# Altium schematic sheet HB_Z6.3.2_HVPSU.SchDoc(HB_Z6.3.2_HVPSU)
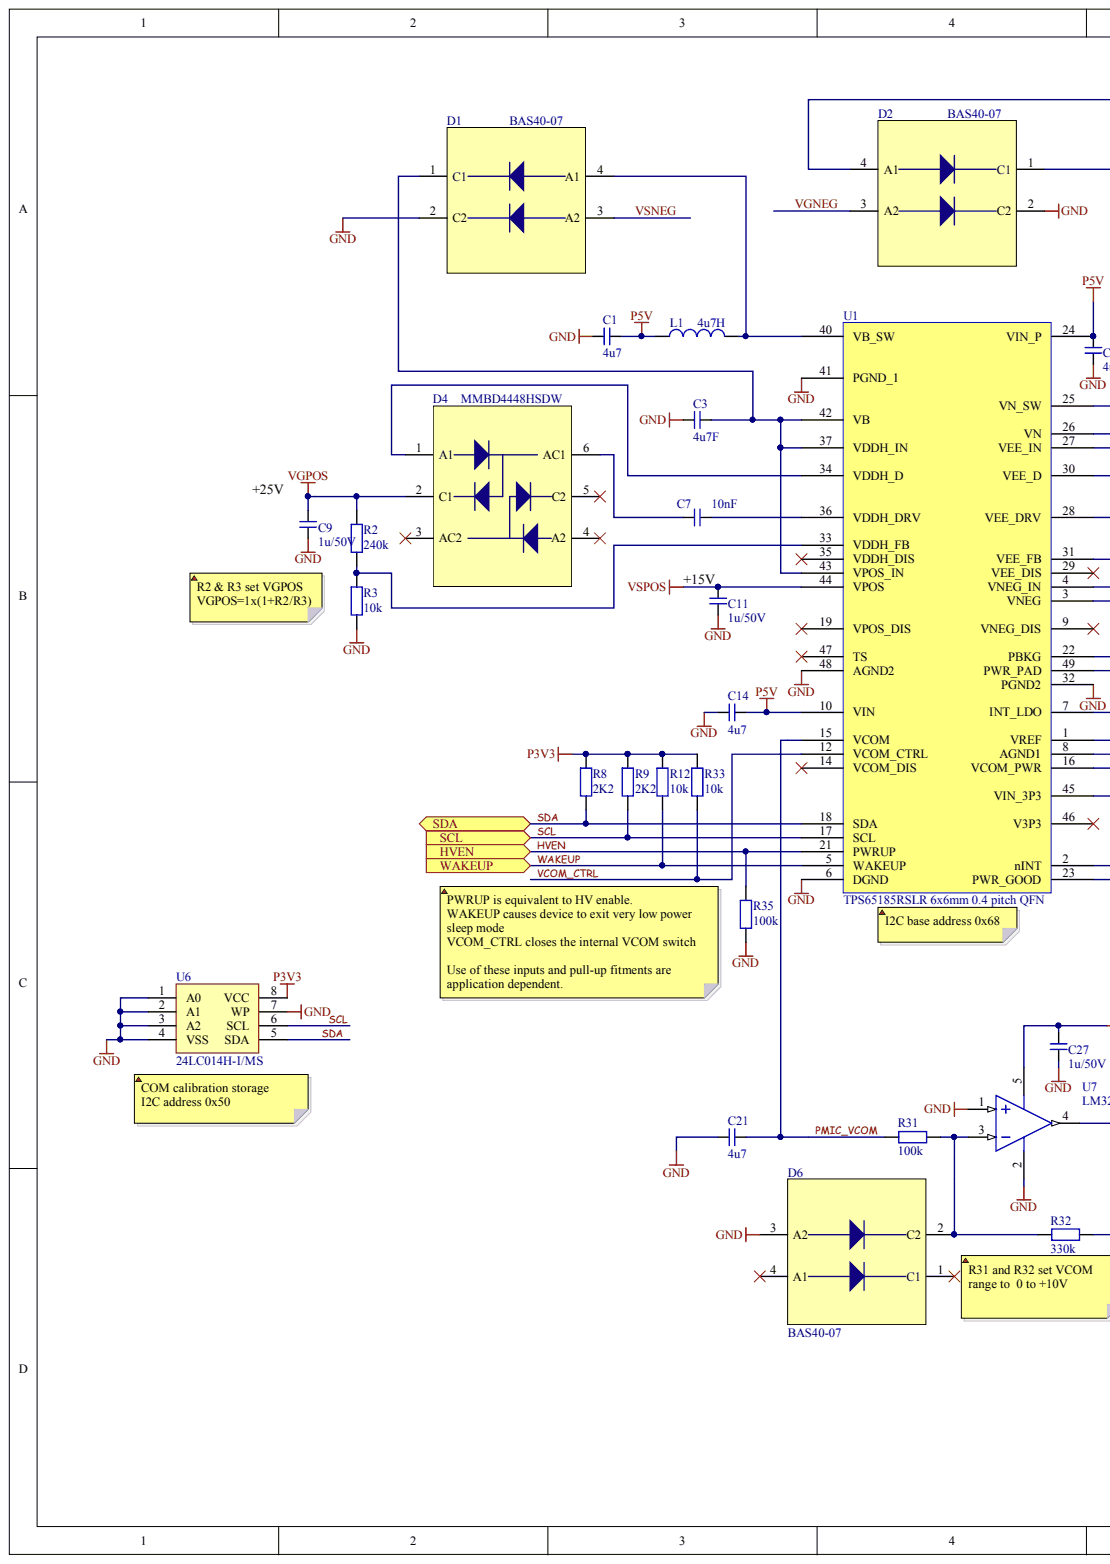
[diagram p0: HB_Z6.3.2_HVPSU.SchDoc, left]
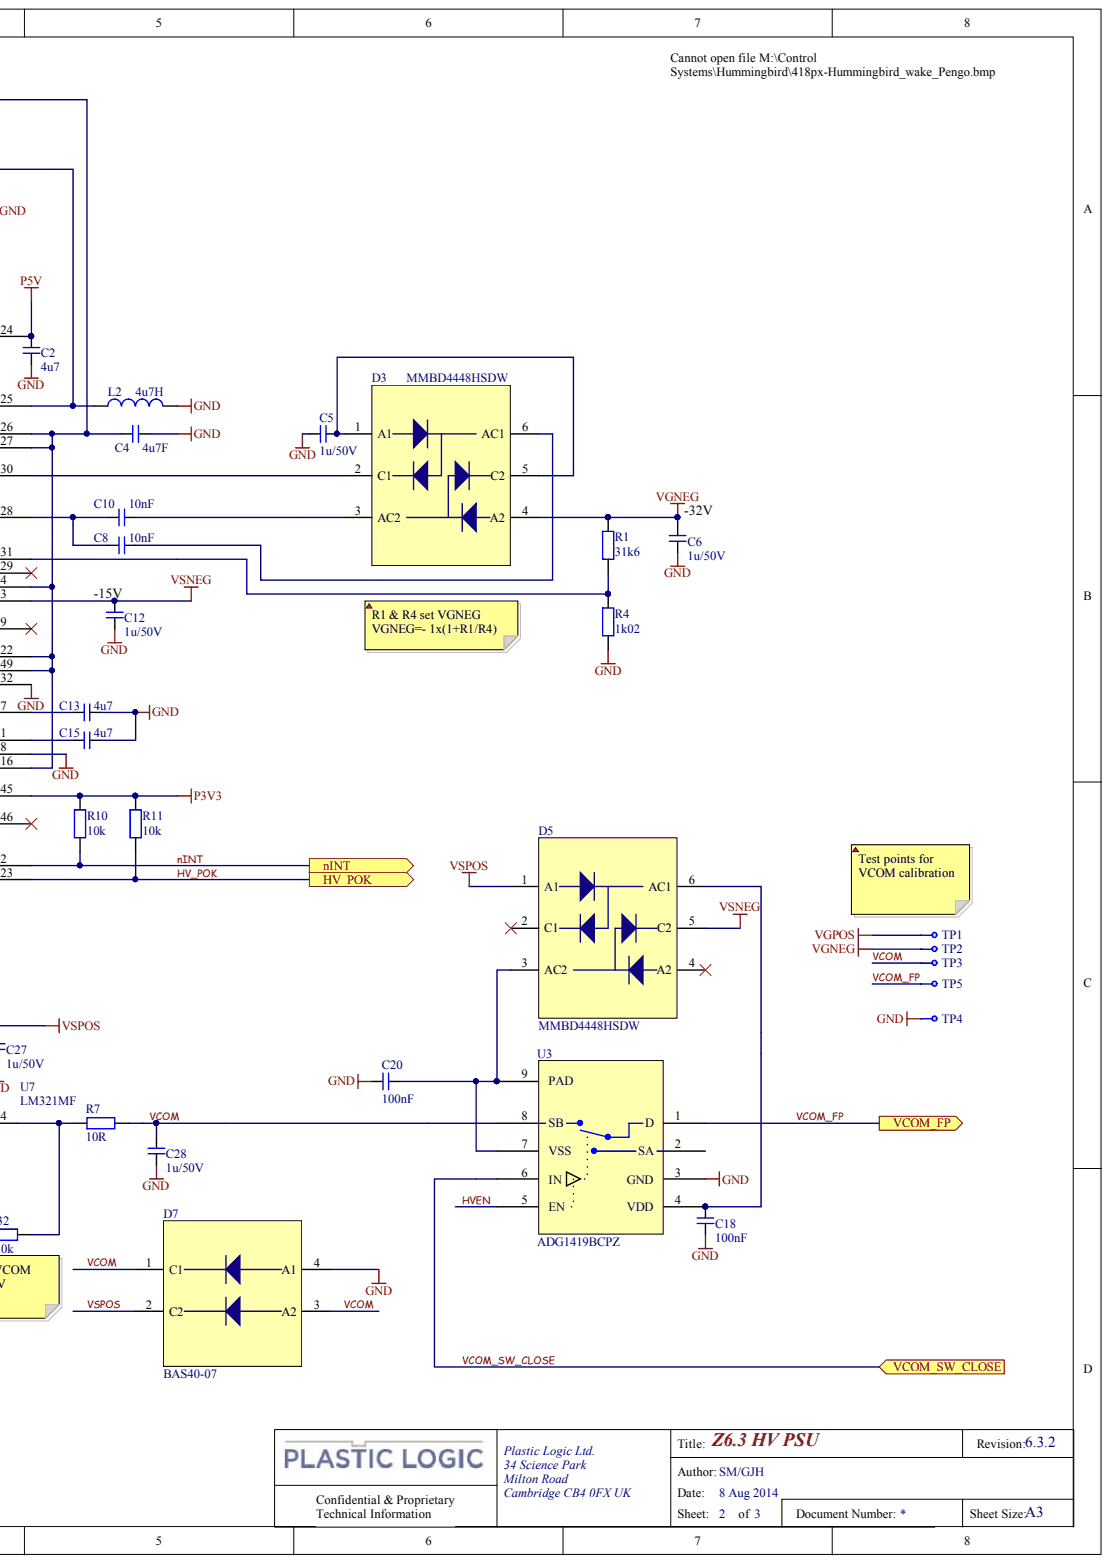
[diagram p1: HB_Z6.3.2_HVPSU.SchDoc, right]

## Parts
C1  4u7  [C0402]
C2  4u7  [C0402]
C3  4u7F  [C0805]
C4  4u7F  [C0805]
C5  1u/50V  [C0603_small]
C6  1u/50V  [C0603_small]
C7  10nF  [C0402]
C8  10nF  [C0402]
C9  1u/50V  [C0603_small]
C10  10nF  [C0402]
C11  1u/50V  [C0603_small]
C12  1u/50V  [C0603_small]
C13  4u7  [C0402]
C14  4u7  [C0402]
C15  4u7  [C0402]
C18  100nF  [C0603_small]
C20  100nF  [C0603_small]
C21  4u7  [C0402]
C27  1u/50V  [C0603_small]
C28  1u/50V  [C0603_small]
D1  BAS40-07  [SOT-143]
D2  BAS40-07  [SOT-143]
D3  MMBD4448HSDW  [SOT-363]
D4  MMBD4448HSDW  [SOT-363]
D5  MMBD4448HSDW  [SOT-363]
D6  BAS40-07  [SOT-143]
D7  BAS40-07  [SOT-143]
L1  4u7H  [Panasonic ELLVGG]
L2  4u7H  [Panasonic ELLVGG]
R1  31k6  [R0402]
R2  240k  [R0402]
R3  10k  [R0402]
R4  1k02  [R0402]
R7  10R  [R0402]
R8  2K2  [R0402]
R9  2K2  [R0402]
R10  10k  [R0402]
R11  10k  [R0402]
R12  10k  [R0402]
R31  100k  [R0402]
R32  330k  [R0402]
R33  10k  [R0402]
R35  100k  [R0402]
TP1  Test Pad  [Test Pad 1mm]  (value not drawn)
TP2  Test Pad  [Test Pad 1mm]  (value not drawn)
TP3  Test Pad  [Test Pad 1mm]  (value not drawn)
TP4  Test Pad  [Test Pad 1mm]  (value not drawn)
TP5  Test Pad  [Test Pad 1mm]  (value not drawn)
U1  TPS65185  value TPS65185RSLR 6x6mm 0.4 pitch QFN  ManufacturerPN: TPS65185RSLR  [Quad48x0.4mm]
U3  ADG1419BCPZ  [LFCSP_DW]
U6  24LC014H-I/MS  [MSOP8]
U7  LM321MF  [SOT23-5]

## Ports
HV_POK, HVEN, NINT, SCL, SDA, VCOM_FP, VCOM_SW_CLOSE, WAKEUP

## Nets
GND: C1.1 C2.2 C3.1 C4.2 C5.2 C6.1 C9.1 C11.2 C12.2 C13.2 C14.1 C15.2 C18.1 C20.2 C21.1 C27.2 C28.2 D1.2(C2) D2.2(C2) D6.3(A2) D7.4(A1) L2.2 R3.2 R4.2 R35.2 TP4.1 U1.6(DGND) U1.8(AGND1) U1.32(PGND2) U1.41(PGND_1) U1.48(AGND2) U3.3(GND) U6.1(A0) U6.2(A1) U6.3(A2) U6.4(VSS) U6.7(WP) U7.1 U7.2
P3V3: R8.1 R9.1 R10.1 R11.1 R12.1 R33.1 U1.45(VIN_3P3) U6.8(VCC)
P5V: C1.2 C2.1 C14.2 L1.1 U1.10(VIN) U1.24(VIN_P)
VGPOS: C9.2 D4.2(C1) R2.1 TP1.1
HV_POK: R11.2 U1.23(PWR_GOOD) ; port HV_POK
HVEN: R35.1 U1.21(PWRUP) U3.5(EN) ; port HVEN
NINT: R10.2 U1.2(nINT) ; port NINT
PMIC_VCOM: C21.2 R31.1 U1.15(VCOM)
SCL: R9.2 U1.17(SCL) U6.6(SCL) ; port SCL
SDA: R8.2 U1.18(SDA) U6.5(SDA) ; port SDA
VCOM: C28.1 D7.1(C1) D7.3(A2) R7.2 TP3.1 U3.8(SB)
VCOM_CTRL: R33.2 U1.12(VCOM_CTRL)
VCOM_FP: TP5.1 U3.1(D) ; port VCOM_FP
VCOM_SW_CLOSE: U3.6(IN) ; port VCOM_SW_CLOSE
VGNEG: C6.2 D2.3(A2) D3.4(A2) R1.1 TP2.1
VSNEG: C12.1 D1.3(A2) D5.5(C2) U1.3(VNEG)
VSPOS: C11.1 C27.1 D5.1(A1) D7.2(C2) U1.44(VPOS) U7.5
WAKEUP: R12.2 U1.5(WAKEUP) ; port WAKEUP
NetC3_2: C3.2 D1.1(C1) U1.37(VDDH_IN) U1.42(VB) U1.43(VPOS_IN)
NetC4_1: C4.1 D2.4(A1) U1.4(VNEG_IN) U1.16(VCOM_PWR) U1.22(PBKG) U1.26(VN) U1.27(VEE_IN) U1.49(PWR_PAD)
NetC5_1: C5.1 D3.1(A1) D3.5(C2)
NetC7_1: C7.1 U1.36(VDDH_DRV)
NetC7_2: C7.2 D4.6(AC1)
NetC8_1: C8.1 D3.6(AC1)
NetC8_2: C8.2 C10.2 U1.28(VEE_DRV)
NetC10_1: C10.1 D3.3(AC2)
NetC13_1: C13.1 U1.7(INT_LDO)
NetC15_1: C15.1 U1.1(VREF)
NetC18_2: C18.2 D5.6(AC1) U3.4(VDD)
NetC20_1: C20.1 D5.3(AC2) U3.7(VSS) U3.9(PAD)
NetD1_4: D1.4(A1) L1.2 U1.40(VB_SW)
NetD2_1: D2.1(C1) L2.1 U1.25(VN_SW)
NetD3_2: D3.2(C1) U1.30(VEE_D)
NetD4_1: D4.1(A1) U1.34(VDDH_D)
NetD6_2: D6.2(C2) R31.2 R32.1 U7.3
NetR1_2: R1.2 R4.1 U1.31(VEE_FB)
NetR2_2: R2.2 R3.1 U1.33(VDDH_FB)
NetR7_1: R7.1 R32.2 U7.4

Not connected: D4 3(AC2) 4(A2) 5(C2); D5 2(C1) 4(A2); D6 1(C1) 4(A1); U1 9(VNEG_DIS) 14(VCOM_DIS) 19(VPOS_DIS) 29(VEE_DIS) 35(VDDH_DIS) 46(V3P3) 47(TS); U3 2(SA)

## Library data of the parts
C1 C2 C13-C15 C21 = ChipCap0402: 0402 Capacitor; Manufacturer: TDK; ManufacturerPN: C1005X5R0J475M050BC; Fitted: I; Material: X5R; Order Code: 2309027; Tolerance: 20%; Voltage: 6.3V; Component Kind: Standard; Supplier: Farnell; Value: 4u7; Library Name: PlasticLogicLIB.SchLib
C3 C4 = ChipCap0805: 0805 Capacitor; Manufacturer: Kemet; ManufacturerPN: C0805C475K3PACTU; Fitted: I; Material: X5R; Order Code: 1572631RL; Tolerance: 10%; Voltage: 25V; Component Kind: Standard; Supplier: Farnell; Value: 4u7F; Library Name: PlasticLogicLIB.SchLib
C5 C6 C9 C11 C12 C27 C28 = ChipCap0603: 0603 Capacitor; Manufacturer: MURATA; ManufacturerPN: GRM188R61H105KAALD; Fitted: I; Material: X5R; Order Code: 1845736; Tolerance: 10%; Voltage: 50V; Component Kind: Standard; Supplier: Farnell; Value: 1u/50V; Library Name: PlasticLogicLIB.SchLib
C7 C8 C10 = ChipCap0402: 0402 Capacitor; Manufacturer: Kemet; ManufacturerPN: C0402C103K5RACTU; Fitted: I; Material: X7R; Order Code: 1414575RL; Tolerance: 10%; Voltage: 50V; Component Kind: Standard; Supplier: Farnell; Value: 10nF; Library Name: PlasticLogicLIB.SchLib
C18 C20 = ChipCap0603: 0603 Capacitor; Manufacturer: AVX; ManufacturerPN: 06033C104JAT2A; Fitted: I; Material: X7R; Order Code: 1740614; Tolerance: 10%; Voltage: 25V; Component Kind: Standard; Supplier: Farnell; Value: 100nF; Library Name: PlasticLogicLIB.SchLib
D1 D2 D6 D7 = Diode_array_2individ: Diode array, 2 individual, schottky; Manufacturer: NXP; Fitted: I; Order Code: 8734364; Component Kind: Standard; ManufacturerPN: BAS40-07; Supplier: Farnell; Value: BAS40-07; Library Name: PlasticLogicLIB.SchLib
D3-D5 = Diode_array_4series: Diode array, 2 pairs, common A&C; Manufacturer: Diodes Inc; Fitted: I; Order Code: 1773528; Component Kind: Standard; ManufacturerPN: MMBD4448HSDW; Supplier: Farnell; Value: MMBD4448HSDW; Library Name: PlasticLogicLIB.SchLib
L1 L2 = Inductor: 4u7 low profile inductor; Manufacturer: Panasonic; ManufacturerPN: Panasonic ELLVGG4R7N; Fitted: I; Order code: 1717414; Tolerance: 30%; Component Kind: Standard; Supplier: Farnell; Value: 4u7H; Library Name: PlasticLogicLIB.SchLib
R1 = ChipRes0402: 0402 Resistor; Manufacturer: VISHAY DRALORIC; ManufacturerPN: CRCW040231K6FKED; Fitted: I; Order Code: 1652784; Power: 0.0625W; Tolerance: 1%; Voltage: 50V; Component Kind: Standard; Material: Thick Film; Supplier: Farnell; Value: 31k6; Library Name: PlasticLogicLIB.SchLib
R2 = ChipRes0402: 0402 Resistor; Manufacturer: VISHAY DRALORIC; ManufacturerPN: CRCW0402240KFKED; Fitted: I; Order Code: 2140964RL; Power: 0.0625W; Tolerance: 1%; Voltage: 50V; Component Kind: Standard; Material: Thick Film; Supplier: Farnell; Value: 240k; Library Name: PlasticLogicLIB.SchLib
R3 R10-R12 R33 = ChipRes0402: 0402 Resistor; Manufacturer: VISHAY DRALORIC; ManufacturerPN: CRCW040210K0FKED; Fitted: I; Order Code: 1469669RL; Power: 0.0625W; Tolerance: 1%; Voltage: 50V; Component Kind: Standard; Material: Thick Film; Supplier: Farnell; Value: 10k; Library Name: PlasticLogicLIB.SchLib
R4 = ChipRes0402: 0402 Resistor; Manufacturer: VISHAY DRALORIC; ManufacturerPN: CRCW04021K02FKED; Fitted: I; Order Code: 2140775; Power: 0.0625W; Tolerance: 1%; Voltage: 50V; Component Kind: Standard; Material: Thick Film; Supplier: Farnell; Value: 1k02; Library Name: PlasticLogicLIB.SchLib
R7 = ChipRes0402: 0402 Resistor; Manufacturer: VISHAY DRALORIC; ManufacturerPN: CRCW040210R0FKED; Fitted: I; Order Code: 1652742RL; Power: 0.0625W; Tolerance: 1%; Voltage: 50V; Component Kind: Standard; Material: Thick Film; Supplier: Farnell; Value: 10R; Library Name: PlasticLogicLIB.SchLib
R8 R9 = ChipRes0402: 0402 Resistor; Manufacturer: VISHAY DRALORIC; ManufacturerPN: CRCW04022K20FKED; Fitted: I; Order Code: 2140802; Power: 0.0625W; Tolerance: 1%; Voltage: 50V; Component Kind: Standard; Material: Thick Film; Supplier: Farnell; Value: 2K2; Library Name: PlasticLogicLIB.SchLib
R31 R35 = ChipRes0402: 0402 Resistor; Manufacturer: VISHAY DRALORIC; ManufacturerPN: CRCW0402100KFKED; Fitted: I; Order Code: 1469671RL; Power: 0.0625W; Tolerance: 1%; Voltage: 50V; Component Kind: Standard; Material: Thick Film; Supplier: Farnell; Value: 100k; Library Name: PlasticLogicLIB.SchLib
R32 = ChipRes0402: 0402 Resistor; Manufacturer: VISHAY DRALORIC; ManufacturerPN: CRCW0402330KFKED; Fitted: I; Order Code: 2140980RL; Power: 0.0625W; Tolerance: 1%; Voltage: 50V; Component Kind: Standard; Material: Thick Film; Supplier: Farnell; Value: 330k; Library Name: PlasticLogicLIB.SchLib
TP1-TP5 = Test Pad_1: ManufacturerPN: PCB FEATURE; Fitted: I; Component Kind: Standard; Library Name: PlasticLogicLIB.SchLib
U1 = TPS65185: Texas Ins.TPS65185 HVPMIC; Manufacturer: Texas Inst; Fitted: I; Component Kind: Standard; Supplier: Mouser; Library Name: PlasticLogicLIB.SchLib
U3 = ADG1419: analogue sw SPDT, QFN8 (LFCSP); Manufacturer: Analogue Devices; Fitted: I; Order Code: ADG1419BCPZ-reel7CT-ND; Component Kind: Standard; Supplier: Digikey; Value: ADG1419BCPZ; Library Name: PlasticLogicLIB.SchLib
U6 = 24AA256: 1k 12C EEPROM; Manufacturer: Microchip; Fitted: I; Order Code: 1659737; Component Kind: Standard; ManufacturerPN: 24LC014H-I/MS; Supplier: Farnell; Value: 24LC014; Library Name: PlasticLogicLIB.SchLib
U7 = LM321MF: Manufacturer: NatSemi; ManufacturerPN: LM321MF/NOPB; Fitted: I; Order Code: 1468874RL; Component Kind: Standard; Supplier: Farnell; Value: LM321MF; Library Name: PlasticLogicLIB.SchLib
